# Altium schematic sheet SPI to CAN Converter.SchDoc
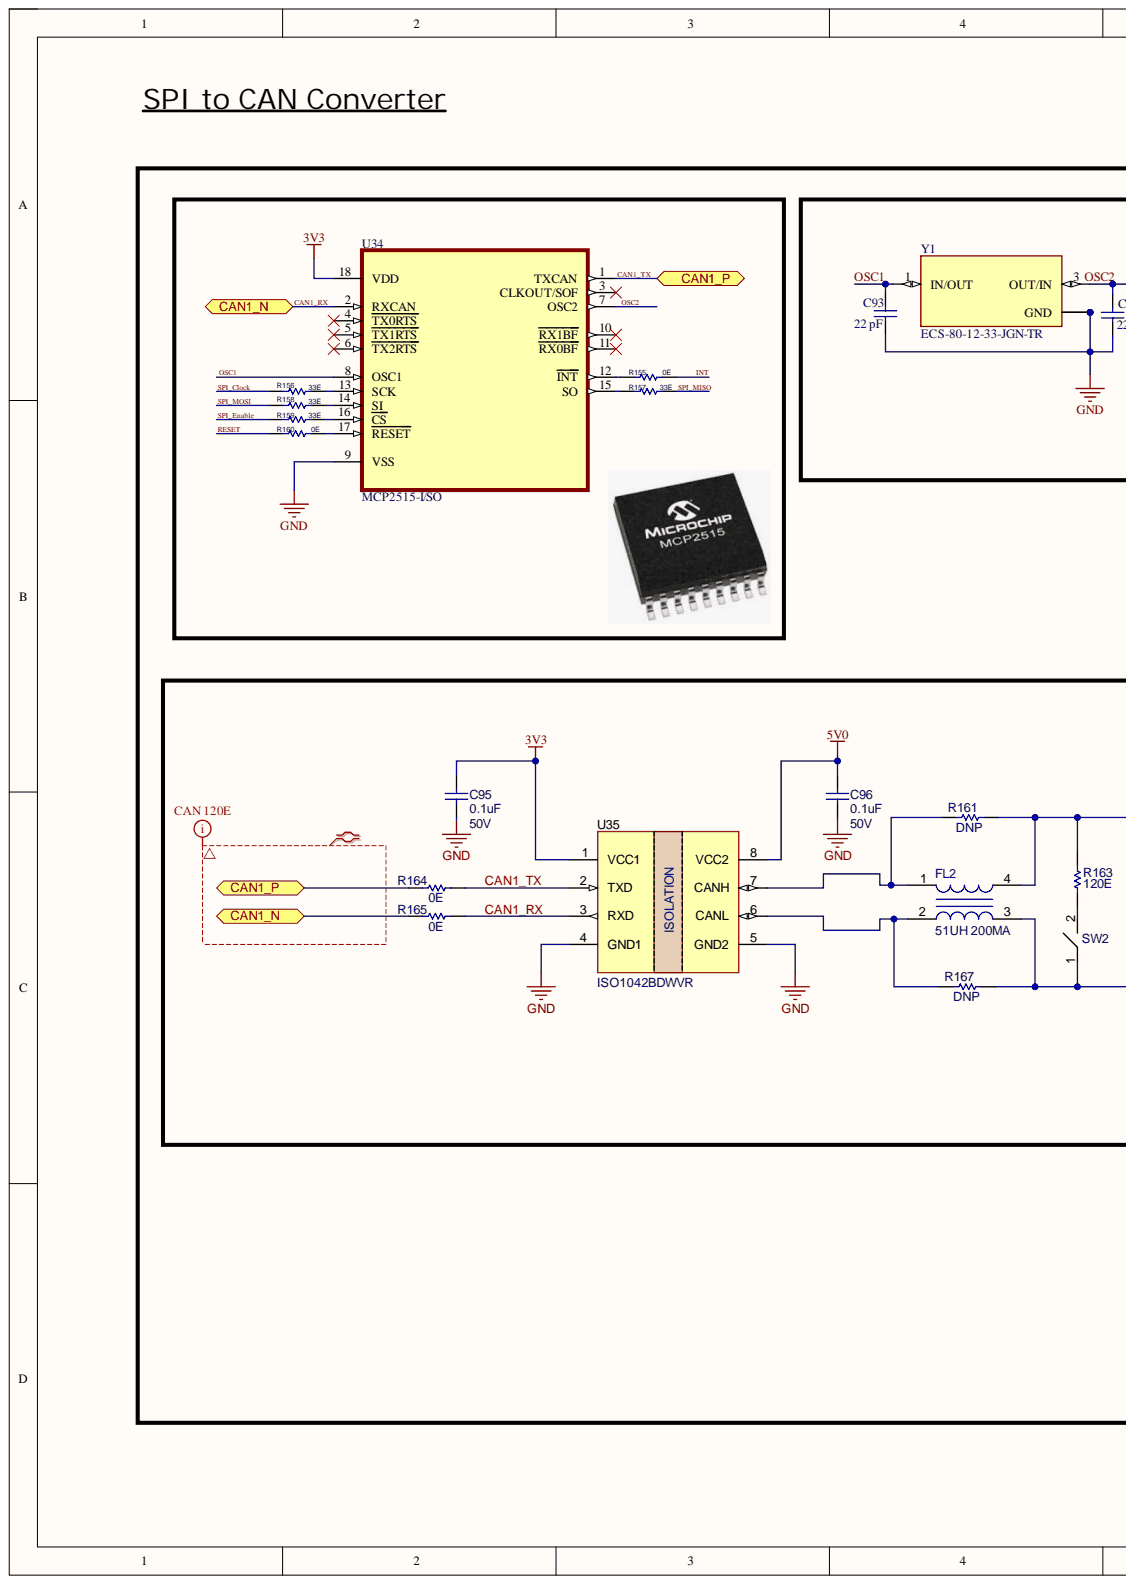
[diagram p0: SPI to CAN Converter.SchDoc, left]
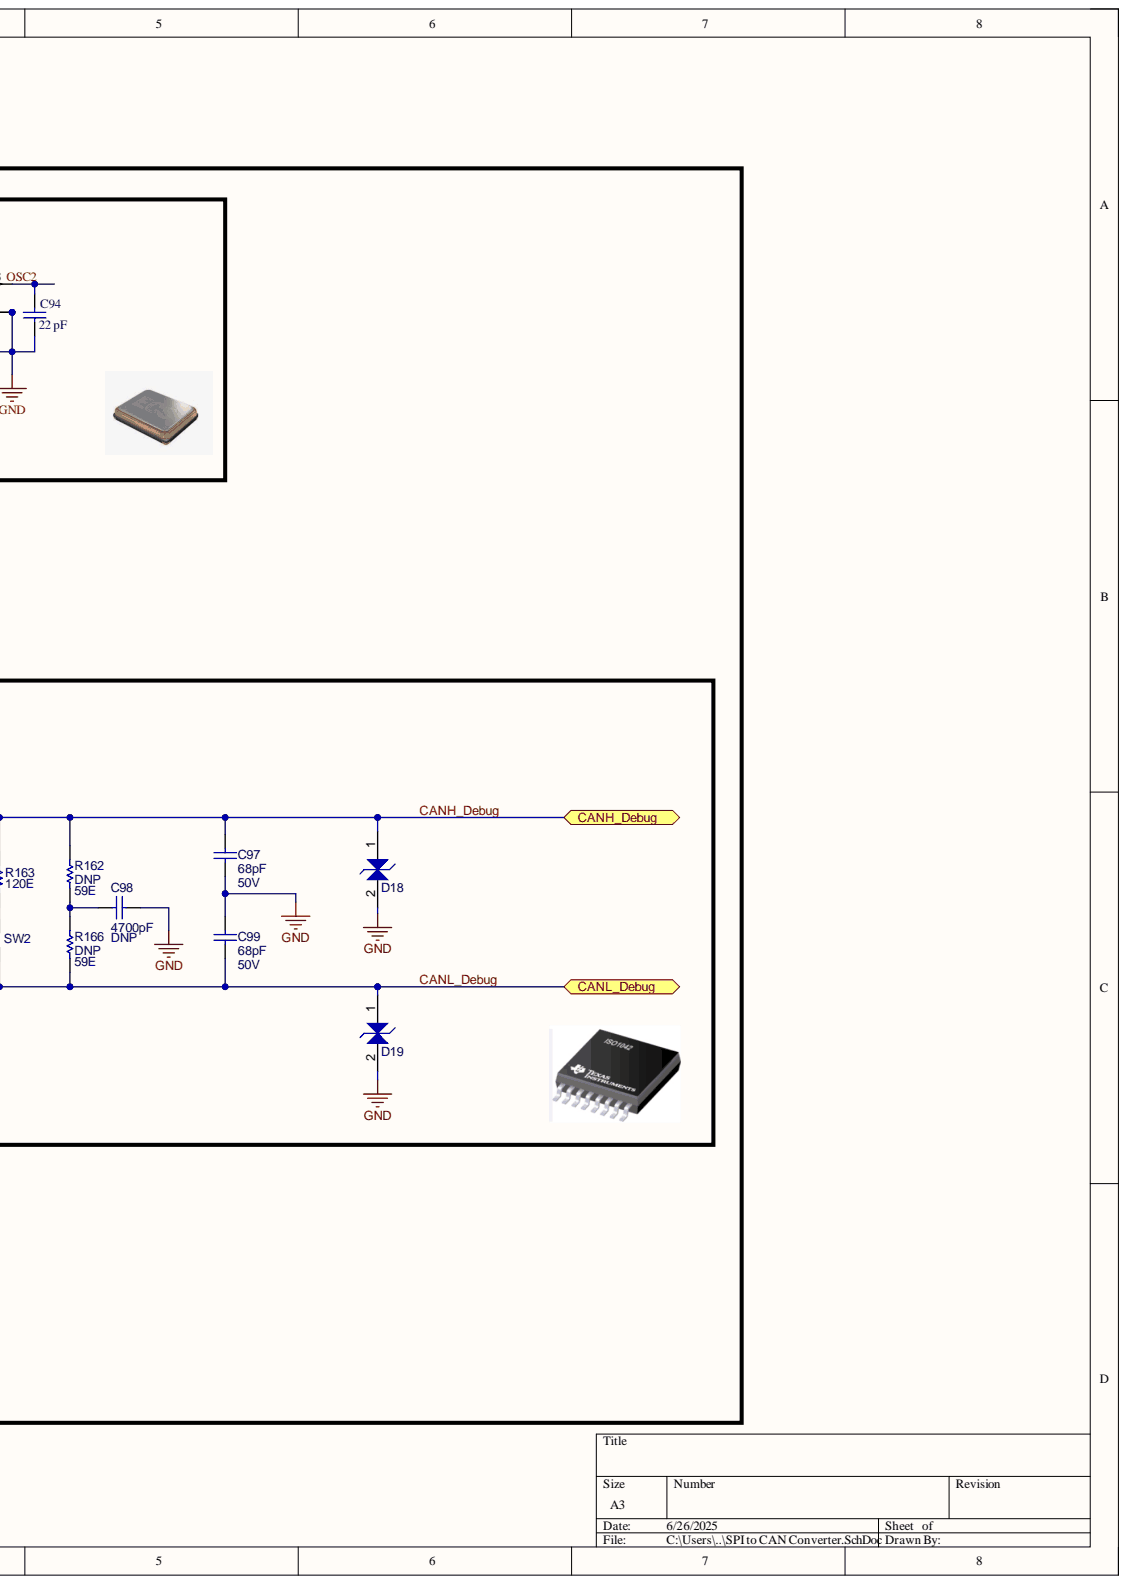
[diagram p1: SPI to CAN Converter.SchDoc, right]

## Parts
C93  22 pF  [CAP-0805]
C94  22 pF  [CAP-0805]
C95  0.1uF  Voltage: 50V  [CAP-0805]
C96  0.1uF  Voltage: 50V  [CAP-0805]
C97  68pF  Voltage: 50V  [CAP-0603]
C98  DNP  value 4700pF  [CAP-0603]
C99  68pF  Voltage: 50V  [CAP-0603]
D18  SMAJ5.0CA  [DO-214AC]  (value not drawn)
D19  SMAJ5.0CA  [DO-214AC]  (value not drawn)
FL2  51UH 200MA  [FIL_ACT45B-510-2P-TL003]
R155  0E  [RES-0603]
R156  33E  [RES-0603]
R157  33E  [RES-0603]
R158  33E  [RES-0603]
R159  33E  [RES-0603]
R160  0E  [RES-0603]
R161  DNP  [RES-0603]
R162  DNP  value 59E  [RES-0603]
R163  120E  [RES-0603]
R164  0E  [RES-0603]
R165  0E  [RES-0603]
R166  DNP  value 59E  [RES-0603]
R167  DNP  [RES-0603]
SW2  DS04-254-2-01BK-SMT  [SW_DS04-254-2-01BK-SMT-TR]  (value not drawn)
U34  MCP2515-I/SO  [SOIC127P1034X264-18N]
U35  ISO1042BDWVR  [D0008A_N]
Y1  ECS-80-12-33-JGN-TR  [8MHZCrystall]

## Ports
CAN1_N, CAN1_P, CANH_Debug, CANL_Debug

## Nets
3V3: C95.2 U34.18(VDD) U35.1(VCC1)
5V0: C96.2 U35.8(VCC2)
GND: C95.1 C96.1 C97.2 C98.1 C99.1 D18.2 D19.2 U34.9(VSS) U35.4(GND1) U35.5(GND2)
CAN1_RX: R165.1 R165.2 U34.2(RXCAN) U35.3(RXD) ; port CAN1_N
CAN1_TX: R164.1 R164.2 U34.1(TXCAN) U35.2(TXD) ; port CAN1_P
CANH_Debug: C97.1 D18.1 FL2.4 R161.1 R162.2 R163.2 ; port CANH_Debug
CANL_Debug: C99.2 D19.1 FL2.3 R166.1 R167.1 SW2.1 ; port CANL_Debug
INT: R155.2
OSC1: C93.2 U34.8(OSC1) Y1.1(IN/OUT)
OSC2: U34.7(OSC2)
RESET: R160.1
SPI_Clock: R156.2
SPI_Enable: R159.2
SPI_MISO: R157.1
SPI_MOSI: R158.2
NetC93_2: C93.2 C94.2 Y1.2(GND) Y1.4
NetC94_2: C94.2 Y1.3(OUT/IN)
NetC98_2: C98.2 R162.1 R166.2
NetFL2_1: FL2.1 R161.2 U35.7(CANH)
NetFL2_2: FL2.2 R167.2 U35.6(CANL)
NetR155_1: R155.1 U34.12(INT)
NetR156_1: R156.1 U34.13(SCK)
NetR157_2: R157.2 U34.15(SO)
NetR158_1: R158.1 U34.14(SI)
NetR159_1: R159.1 U34.16(CS)
NetR160_2: R160.2 U34.17(RESET)
NetR163_1: R163.1 SW2.2

Not connected: U34 3(CLKOUT/SOF) 4(TX0RTS) 5(TX1RTS) 6(TX2RTS) 10(RX1BF) 11(RX0BF)

## Library data of the parts
C93 C94 = CAP_22 pF ±5% 16V Ceramic Capacitor X7R 0805: 2.2 µF ±5% 16V Ceramic Capacitor X7R 0805 (2012 Metric), C0805C225J4RECTU; Component Kind: Standard; Datasheet: https://content.kemet.com/datasheets/KEM_C1090_X7R_ESD.pdf; Library Name: GENSET CONTROLLER BETA V1.01.SCHLIB
C95 C96 = CAP: CAP CER 0.1UF 50V X7R 0805; Manufacturer: KYOCERA AVX; Part Number: 08055C104J4Z2A; Component Kind: Standard; Library Name: CAN_20-06-2023.SCHLIB; Package: 0603; Value: 0.1uF
C97 C99 = CAP: CAP CER 68PF 50V C0G/NP0 0603; Manufacturer: KEMET; Part Number: C0603C680J5GACAUTO; Component Kind: Standard; Library Name: CAN_20-06-2023.SCHLIB; Package: 0603; Value: 68pF
C98 = CAP: CAP CER 4700PF 50V C0G/NP0 0603; Manufacturer: Murata Electronics; Part Number: GRM1885C1H472JA01D; Component Kind: Standard; Library Name: CAN_20-06-2023.SCHLIB; Package: 0603; Voltage: 50V
D18 D19 = SMCJ12CA: TVS DIODE 5VWM 9.2VC DO214AC; Manufacturer: Littelfuse Inc.; Value: 5V; Component Kind: Standard; Library Name: SamacSys.SchLib; Package: DO-214AC; Part Number: SMAJ5.0CA
FL2 = ACT45B-510-2P-TL003: CMC 51UH 200MA 2LN 2KOHM SMD; Manufacturer: Eaton - Electronics Division; Part Number: ACE1V4532-510-R; Component Kind: Standard; Package: 0.177" L x 0.126" W (4.50mm x 3.20mm); Value: 51uH
R155 R160 = RES-2: RES SMD 0 OHM JUMPER 1/10W 0603; Manufacturer: YAGEO; Part Number: AC0603FR-070RL; Power: 0.1W; Tolerance: ±1%; Component Kind: Standard; Library Name: Daughter_board_SE3_V1.SCHLIB; Package: 0603; Value: 0E
R156-R159 = RES-2: RES SMD 33 OHM 5% 1/10W 0603; Manufacturer: YAGEO; Part Number: AC0603JR-0733RL; Power: 0.1W; Tolerance: ±1%; Component Kind: Standard; Library Name: Daughter_board_SE3_V1.SCHLIB; Package: 0603; Value: 33E
R161 R167 = RES-2: RES 0 OHM JUMPER 1/10W 0603; Manufacturer: YAGEO; Part Number: AC0603JR-130RL; Power: 0.1W; Tolerance: ±1%; Value: 0E; Component Kind: Standard; Library Name: Daughter_board_SE3_V1.SCHLIB; Package: 0603
R162 R166 = Resistor: RES SMD 59 OHM 1% 1/10W 0603; Manufacturer: YAGEO; Part Number: AC0603FR-0759RL; Component Kind: Standard; Library Name: Miscellaneous Devices.IntLib; Tolerance: ±1%
  R166: ComponentLink1Description: Datasheet link; ComponentLink1URL: http://www.yageo.com/pdf/Pu-RC0402_51_PbFree_L_2.pdf
R163 = Resistor: RES SMD 120 OHM 1% 1/10W 0603; Manufacturer: YAGEO; Part Number: AC0603FR-07120RL; Component Kind: Standard; Library Name: Miscellaneous Devices.IntLib; Package / Case: 0603 (1608 Metric); Tolerance: ±1%; Value: 120E
R164 R165 = RES-2: RES 0 OHM JUMPER 1/10W 0603; Manufacturer: YAGEO; Part Number: AC0603JR-130RL; Power: 0.1W; Tolerance: ±1%; Component Kind: Standard; Library Name: Daughter_board_SE3_V1.SCHLIB; Package: 0603; Value: 0E
SW2 = DS04-254-2-01BK-SMT: SWITCH SLIDE DIP SPST 0.025A 24V; Manufacturer: Same Sky (Formerly CUI Devices); Component Kind: Standard; Part Number: DS04-254-2-01BK-SMT
U34 = MCP2515-I/SO: CANbus Controller CAN 2.0 SPI Interface 18-SOIC; MF: Motorola; MP: MCP2515I/SO; Availability: Unavailable; Component Kind: Standard; Library Name: MCP2515-I_SO.IntLib; Package: SOIC-18 Motorola; Price: None; SnapEDA_Link: https://www.snapeda.com/parts/MCP2515I/SO/Motorola/view-part/1413412/?ref=snap
U35 = TCAN332: IC TRANSCEIVER FULL 1/1 8SOIC; Manufacturer: Texas Instruments; Component Kind: Standard; Library Name: Miscellaneous Devices.IntLib; Part Number: ISO1042BDWVR
Y1 = ECS-80-12-33-JGN-TR: 8MHz Â±20ppm Crystal 12pF 400 Ohms 4-SMD, No Lead; MF: ECS Inc.; Availability: Warning; BuyPart: https://www.snapeda.com/parts/ECS-80-12-33-JGN-TR/ECS%20Inc./view-part/4477300/?ref=eda; Component Kind: Standard; Mouser-Purchase-URL: https://snapeda.com/shop?store=Mouser&id=4477300; MP: ECS-80-12-33-JGN-TR; Package: SMD-4 ECS International; Price: None; SnapEDA_Link: https://www.snapeda.com/parts/ECS-80-12-33-JGN-TR/ECS%20Inc./view-part/4477300/?ref=snap; Library Name: smartmcu.IntLib
